# Altium schematic sheet MCU.SchDoc(MCU)
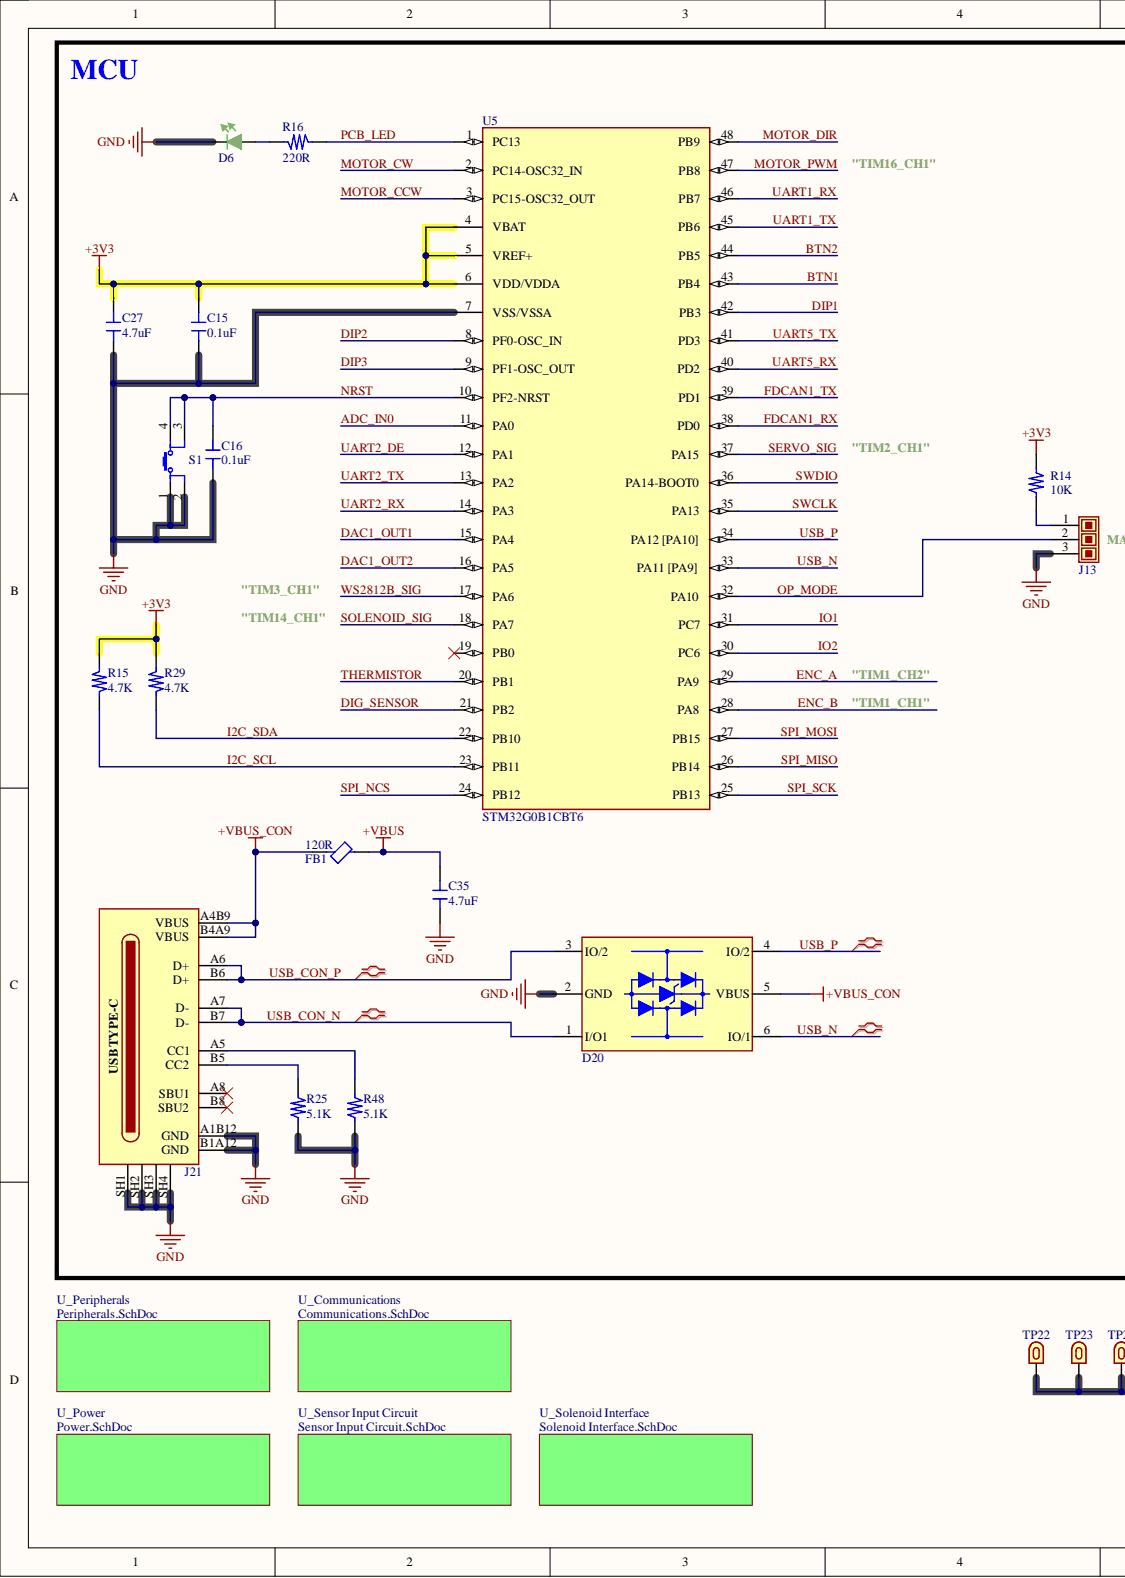
[diagram p0: MCU.SchDoc, left]
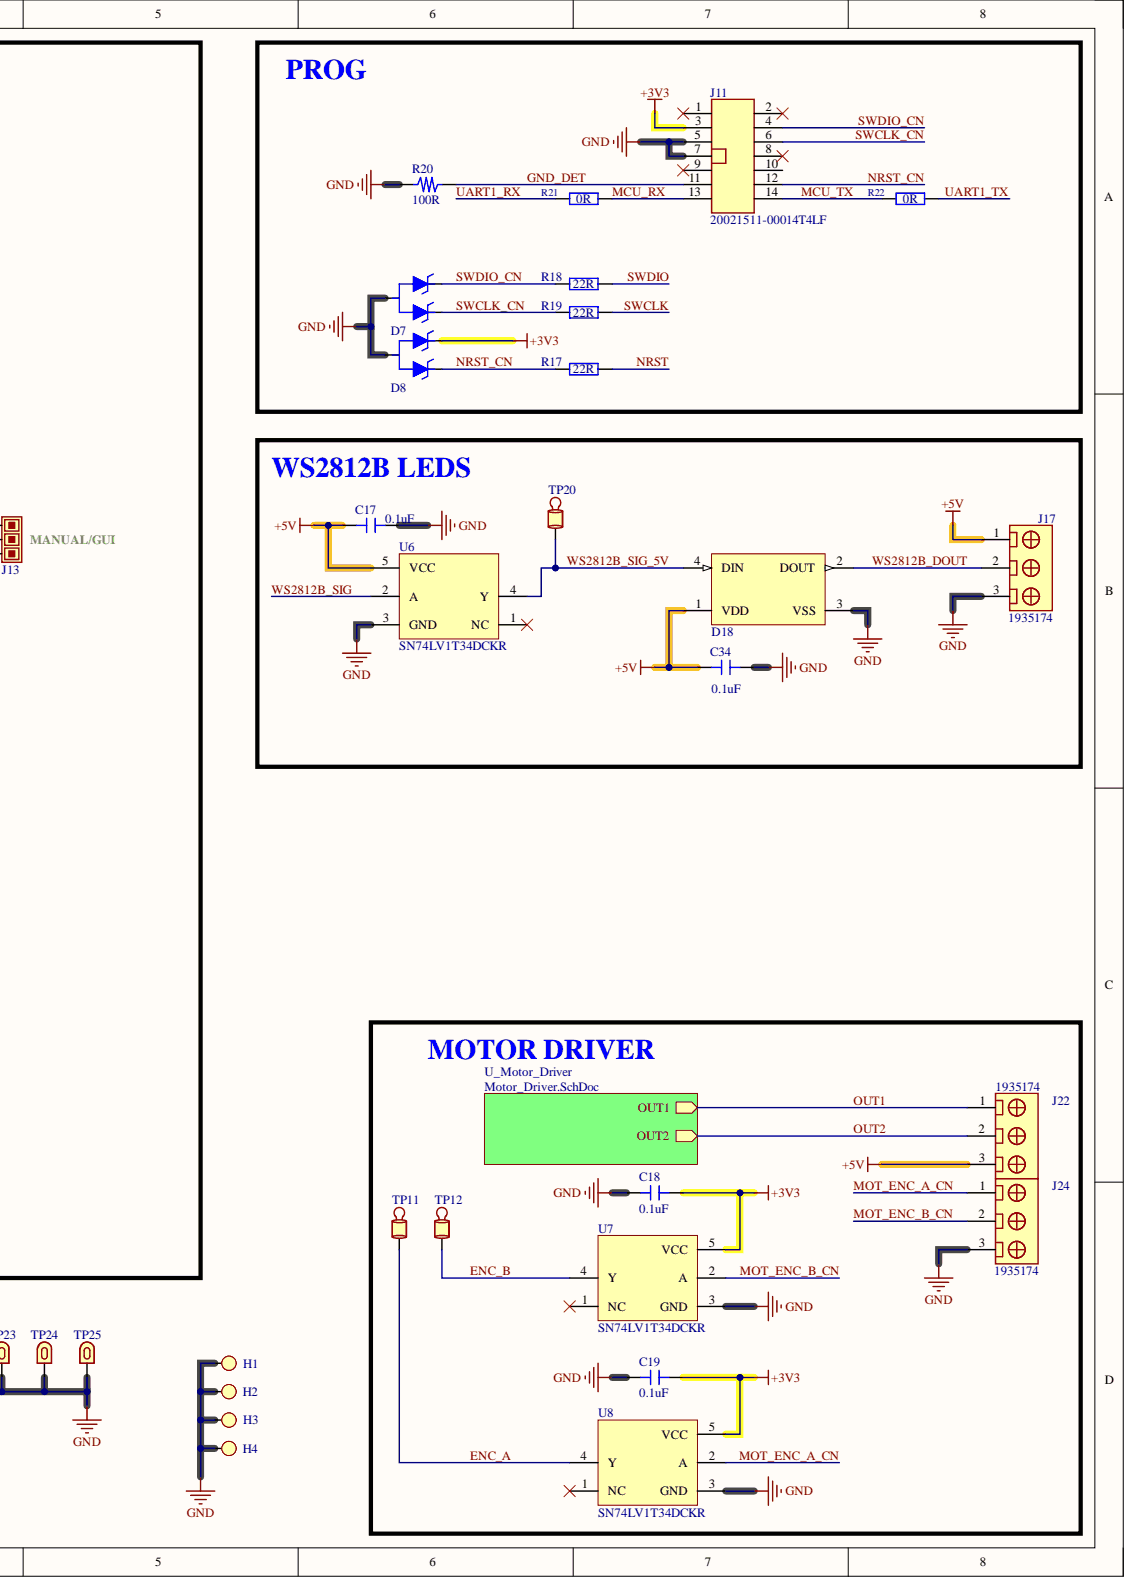
[diagram p1: MCU.SchDoc, right]

## Parts
C15  value 0.1uF  [CAP0603]  (hidden comment: GRM188R72A104KA35D)
C16  value 0.1uF  [CAP0603]  (hidden comment: GRM188R72A104KA35D)
C17  value 0.1uF  [CAP0603]  (hidden comment: GRM188R72A104KA35D)
C18  value 0.1uF  [CAP0603]  (hidden comment: GRM188R72A104KA35D)
C19  value 0.1uF  [CAP0603]  (hidden comment: GRM188R72A104KA35D)
C27  value 4.7uF  [CAP0603]  (hidden comment: GRM188Z71C475KE21D)
C34  value 0.1uF  [CAP0603]  (hidden comment: GRM188R72A104KA35D)
C35  value 4.7uF  [CAP0603]  (hidden comment: GRM188Z71C475KE21D)
D6  LG R971-KN-1-0-20-R18  [LED0805]  (value not drawn)
D7  DF3A6.8FUT1G  [SC70-3(SOT-323)]  (value not drawn)
D8  DF3A6.8FUT1G  [SC70-3(SOT-323)]  (value not drawn)
D18  16347  [LED5050]  (value not drawn)
D20  USBLC6-2SC6  [SOT23-6]  (value not drawn)
FB1  value 120R  [FER0603]  (hidden comment: BLM18KG121TN1D)
H1  MH3.2  [MoutingHole-M3.2_Plated]  (value not drawn)  (no BOM)
H2  MH3.2  [MoutingHole-M3.2_Plated]  (value not drawn)  (no BOM)
H3  MH3.2  [MoutingHole-M3.2_Plated]  (value not drawn)  (no BOM)
H4  MH3.2  [MoutingHole-M3.2_Plated]  (value not drawn)  (no BOM)
J11  20021511-00014T4LF  [20021511-00014T4LF]
J13  68001-203HLF  [3P-2.54mm-MalePins]  (value not drawn)
J17  1935174  [1935174]
J21  2171790001  [2171790001]  (value not drawn)
J22  1935174  [1935174]
J24  1935174  [1935174]
R14  value 10K  [RES0603]  (hidden comment: RC0603FR-0710KL)
R15  value 4.7K  [RES0603]  (hidden comment: RC0603FR-074K7L)
R16  value 220R  [RES0603]  (hidden comment: RC0603FR-07220RL)
R17  value 22R  [RES0603]  (hidden comment: RC0603FR-0722RL)
R18  value 22R  [RES0603]  (hidden comment: RC0603FR-0722RL)
R19  value 22R  [RES0603]  (hidden comment: RC0603FR-0722RL)
R20  value 100R  [RES0603]  (hidden comment: RC0603FR-07100RL)
R21  value 0R  [RES0603]  (hidden comment: RC0603FR-070RL)
R22  value 0R  [RES0603]  (hidden comment: RC0603FR-070RL)
R25  value 5.1K  [RES0603]  (hidden comment: RC0603FR-075K1L)
R29  value 4.7K  [RES0603]  (hidden comment: RC0603FR-074K7L)
R48  value 5.1K  [RES0603]  (hidden comment: RC0603FR-075K1L)
S1  PTS815SJM250SMTRLFS  [PTS815SJM250SMTRLFS]  (value not drawn)
TP11  5001  [5001]  (value not drawn)
TP12  5001  [5001]  (value not drawn)
TP20  5001  [5001]  (value not drawn)
TP22  4952  [4952]  (value not drawn)
TP23  4952  [4952]  (value not drawn)
TP24  4952  [4952]  (value not drawn)
TP25  4952  [4952]  (value not drawn)
U5  STM32G0B1CBT6  [LQFP-48(7x7)]
U6  SN74LV1T34DCKR  [SC-70-5]
U7  SN74LV1T34DCKR  [SC-70-5]
U8  SN74LV1T34DCKR  [SC-70-5]

## Nets
+3V3: C15.2 C18.1 C19.1 C27.1 D8.1 J11.3 R14.1 R15.1 R29.1 U5.4(VBAT) U5.5(VREF+) U5.6(VDD/VDDA) U7.5(VCC) U8.5(VCC)
+5V: C17.2 C34.2 D18.1(VDD) J17.1 J22.3 U6.5(VCC)
+VBUS: C35.1 FB1.2
+VBUS_CON: D20.5(VBUS) FB1.1 J21.A4B9(VBUS) J21.B4A9(VBUS)
GND: C15.1 C16.1 C17.1 C18.2 C19.2 C27.2 C34.1 C35.2 D6.K D7.3 D8.3 D18.3(VSS) D20.2(GND) H1.1 H2.1 H3.1 H4.1 J11.5 J11.7 J13.3 J17.3 J21.A1B12(GND) J21.B1A12(GND) J21.SH1 J21.SH2 J21.SH3 J21.SH4 J24.3 R20.2 R25.2 R48.2 S1.1 S1.2 TP22.1 TP23.1 TP24.1 TP25.1 U5.7(VSS/VSSA) U6.3(GND) U7.3(GND) U8.3(GND)
ADC_IN0: U5.11(PA0)
BTN1: U5.43(PB4)
BTN2: U5.44(PB5)
DAC1_OUT1: U5.15(PA4)
DAC1_OUT2: U5.16(PA5)
DIG_SENSOR: U5.21(PB2)
DIP1: U5.42(PB3)
DIP2: U5.8(PF0-OSC_IN)
DIP3: U5.9(PF1-OSC_OUT)
ENC_A: TP11.1 U5.29(PA9) U8.4(Y)
ENC_B: TP12.1 U5.28(PA8) U7.4(Y)
FDCAN1_RX: U5.38(PD0)
FDCAN1_TX: U5.39(PD1)
GND_DET: J11.11 R20.1
I2C_SCL: R15.2 U5.23(PB11)
I2C_SDA: R29.2 U5.22(PB10)
IO1: U5.31(PC7)
IO2: U5.30(PC6)
MCU_RX: J11.13 R21.1
MCU_TX: J11.14 R22.2
MOT_ENC_A_CN: J24.1 U8.2(A)
MOT_ENC_B_CN: J24.2 U7.2(A)
MOTOR_CCW: U5.3(PC15-OSC32_OUT)
MOTOR_CW: U5.2(PC14-OSC32_IN)
MOTOR_DIR: U5.48(PB9)
MOTOR_PWM: U5.47(PB8)
NRST: C16.2 R17.1 S1.3 S1.4 U5.10(PF2-NRST)
NRST_CN: D8.2 J11.12 R17.2
OP_MODE: J13.2 U5.32(PA10)
OUT1: J22.1
OUT2: J22.2
PCB_LED: R16.1 U5.1(PC13)
SERVO_SIG: U5.37(PA15)
SOLENOID_SIG: U5.18(PA7)
SPI_MISO: U5.26(PB14)
SPI_MOSI: U5.27(PB15)
SPI_NCS: U5.24(PB12)
SPI_SCK: U5.25(PB13)
SWCLK: R19.1 U5.35(PA13)
SWCLK_CN: D7.2 J11.6 R19.2
SWDIO: R18.1 U5.36(PA14-BOOT0)
SWDIO_CN: D7.1 J11.4 R18.2
THERMISTOR: U5.20(PB1)
UART1_RX: R21.2 U5.46(PB7)
UART1_TX: R22.1 U5.45(PB6)
UART2_DE: U5.12(PA1)
UART2_RX: U5.14(PA3)
UART2_TX: U5.13(PA2)
UART5_RX: U5.40(PD2)
UART5_TX: U5.41(PD3)
USB_CON_N: D20.1(I/O1) J21.A7(D-) J21.B7(D-)
USB_CON_P: D20.3(IO/2) J21.A6(D+) J21.B6(D+)
USB_N: D20.6(IO/1) U5.33(PA11_[PA9])
USB_P: D20.4(IO/2) U5.34(PA12_[PA10])
WS2812B_DOUT: D18.2(DOUT) J17.2
WS2812B_SIG: U5.17(PA6) U6.2(A)
WS2812B_SIG_5V: D18.4(DIN) TP20.1 U6.4(Y)
NetD6_A: D6.A R16.2
NetJ13_1: J13.1 R14.2
NetJ21_A5: J21.A5(CC1) R48.1
NetJ21_B5: J21.B5(CC2) R25.1

Not connected: J11 1 2 8 9 10; J21 A8(SBU1) B8(SBU2); U5 19(PB0); U6 1(NC); U7 1(NC); U8 1(NC)

## Library data of the parts
C15-C19 C34 = GRM188R72A104KA35D: 0.1 µF ±10% 100V Ceramic Capacitor X7R 0603 (1608 Metric); Manufacturer: Murata Electronics; Component Kind: Standard; ComponentLink1Description: Datasheet; ComponentLink1URL: https://search.murata.co.jp/Ceramy/image/img/A01X/G101/ENG/GRM188R72A104KA35-01.pdf; ComponentLink2Description: DigiKey Link; ComponentLink2URL: https://www.digikey.com/en/products/detail/murata-electronics/GRM188R72A104KA35D/702549; ComponentLink3Description: LCSC Link; ComponentLink3URL: https://lcsc.com/product-detail/Multilayer-Ceramic-Capacitors-MLCC-SMD-SMT_muRata-GRM188R72A104KA35D_C77058.html?s_z=n_GRM188R72A104KA35D; DigiKey PN: 490-3285-1-ND; LCSC PN: C77058; Library Name: 01-Capacitors_0603.IntLib; MPN: GRM188R72A104KA35D; Package: 0603; Temp Coefficient: X7R; Tolerance: ±10%; Voltage Rating: 100V
C27 C35 = GRM188Z71C475KE21D: 4.7 µF ±10% 16V Ceramic Capacitor X7R 0603 (1608 Metric); Manufacturer: Murata Electronics; Component Kind: Standard; ComponentLink1Description: Datasheet; ComponentLink1URL: https://search.murata.co.jp/Ceramy/image/img/A01X/G101/ENG/GRM188Z71C475KE21-01A.pdf; ComponentLink2Description: DigiKey Link; ComponentLink2URL: https://www.digikey.com/en/products/detail/murata-electronics/GRM188Z71C475KE21D/13401930; ComponentLink3Description: LCSC Link; ComponentLink3URL: https://www.lcsc.com/product-detail/C389010.html?s_z=n_GRM188Z71C475KE21D; DigiKey PN: 490-GRM188Z71C475KE21DCT-ND; LCSC PN: C389010; Library Name: 01-Capacitors_0603.IntLib; MPN: GRM188Z71C475KE21D; Package: 0603; Temp Coefficient: X7R; Tolerance: ±10%; Voltage Rating: 16V
D6 = LG R971-KN-1-0-20-R18: Green 570nm LED Indication - Discrete 2.2V 0805 (2012 Metric); Manufacturer: ams-OSRAM USA INC.; Component Kind: Standard; ComponentLink1Description: Datasheet; ComponentLink1URL: https://look.ams-osram.com/m/2da6f2ceeebf8fac/original/LG-R971.pdf; ComponentLink2Description: DigiKey Link; ComponentLink2URL: https://www.digikey.com/en/products/detail/ams-osram-usa-inc/LG-R971-KN-1-0-20-R18/1227925?s=N4IgTCBcDaIDIHEAEAlAnAdgIwFoDSAcjrgAw5hkpYAcIAugL5A; ComponentLink3Description: LCSC Link; ComponentLink3URL: https://lcsc.com/product-detail/LED-Indication-Discrete_OSRAM-LG-R971-KN-1-0-20-R18_C2899888.html?s_z=n_LG%2520R971-KN-1-0-20-R18; DigiKey PN: 475-LGR971-KN-1CT-ND; LCSC PN: C2899888; Library Name: 05-Optoelectronics.IntLib; MPN: LG R971-KN-1-0-20-R18; Package: 0805
D7 D8 = DF3A6.8FUT1G: 16V Clamp 9.37A (8/20µs) Ipp Tvs Diode Surface Mount SC-70-3 (SOT323); Manufacturer: onsemi; Component Kind: Standard; ComponentLink1Description: Datasheet; ComponentLink1URL: https://www.onsemi.com/pdf/datasheet/df3a6.8fut1-d.pdf; ComponentLink2Description: DigiKey Link; ComponentLink2URL: https://www.digikey.co.il/en/products/detail/onsemi/df3a6-8fut1g/918455; ComponentLink3Description: LCSC Link; ComponentLink3URL: https://lcsc.com/product-detail/ESD-and-Surge-Protection-TVS-ESD_onsemi-DF3A6-8FUT1G_C232543.html?s_z=n_DF3A6.8FUT1G; DigiKey PN: DF3A6.8FUT1GOSCT-ND; LCSC PN: C232543; Library Name: 12-Protection-TVS_Diodes.IntLib; MPN: DF3A6.8FUT1G; Package: SC-70-3(SOT323)
D18 = 16347: WS2812B - ADDRESSABLE LED RED/GRN/BLUE; Manufacturer: SparkFun Electronics; Component Kind: Standard; ComponentLink1Description: Datasheet; ComponentLink1URL: https://cdn.sparkfun.com/datasheets/BreakoutBoards/WS2812B.pdf; ComponentLink2Description: DigiKey Link; ComponentLink2URL: https://www.digikey.com/en/products/detail/sparkfun-electronics/16347/11630204; ComponentLink3Description: LCSC Alternative Link; ComponentLink3URL: https://www.lcsc.com/product-detail/C114586.html?s_z=n_ws2812b-b%252Fw; DigiKey Alternative PN: 4544-FZ2812-5050CT-ND; DigiKey PN: 1568-16347CT-ND; LCSC PN: C114586; Library Name: 05-Optoelectronics.IntLib; MPN: 16347; Package: 5050
D20 = USBLC6-2SC6: USB2.0 Data and VBUS, ESD Protection, 17V Clamp 5A (8/20µs) Ipp Tvs Diode Surface Mount SOT-23-6; Manufacturer: STMicroelectronics; Component Kind: Standard; ComponentLink1Description: Datasheet; ComponentLink1URL: https://www.st.com/content/ccc/resource/technical/document/datasheet/06/1d/48/9c/6c/20/4a/b2/CD00050750.pdf/files/CD00050750.pdf/jcr:content/translations/en.CD00050750.pdf; ComponentLink2Description: DigiKey Link; ComponentLink2URL: https://www.digikey.com/en/products/detail/stmicroelectronics/USBLC6-2SC6/1040559; ComponentLink3Description: LCSC Link; ComponentLink3URL: https://www.lcsc.com/product-detail/C7519.html?s_z=n_USBLC6-2; DigiKey PN: 497-5235-1-ND; LCSC PN: C7519; Library Name: 12-Protection-TVS_Diodes.IntLib; MPN: USBLC6-2SC6; Package: SC-70-3(SOT323)
FB1 = BLM18KG121TN1D: 120 Ohms @ 100 MHz 1 Power Line Ferrite Bead 0603 (1608 Metric) 3A 30mOhm; Manufacturer: Murata Electronics; Component Kind: Standard; ComponentLink1Description: Datasheet; ComponentLink1URL: https://www.murata.com/products/productdata/8796738650142/ENFA0003.pdf; ComponentLink2Description: DigiKey Link; ComponentLink2URL: https://www.digikey.com/en/products/detail/murata-electronics/BLM18KG121TN1D/1982762; ComponentLink3Description: LCSC Link; ComponentLink3URL: https://www.lcsc.com/product-detail/C85831.html?s_z=n_BLM18KG121TN1D; DigiKey PN: 490-5254-1-ND; LCSC PN: C85831; Library Name: 21-Filters.IntLib; MPN: BLM18KG121TN1D; Package: 0603
H1-H4 = MountingHole M3.2-Plated: Description: MountingHole M3.2-Plated; Library Name: 10-Mechanical.IntLib
J11 = 20021511-00014T4LF: Connector Header Through Hole 14 position 0.050" (1.27mm); Manufacturer: Amphenol ICC (FCI); Component Kind: Standard; ComponentLink1Description: Datasheet; ComponentLink1URL: https://cdn.amphenol-cs.com/media/wysiwyg/files/documentation/datasheet/boardwiretoboard/bwb_minitek127_btb.pdf; ComponentLink2Description: DigiKey Link; ComponentLink2URL: https://www.digikey.com/en/products/detail/amphenol-cs-fci/20021511-00014T4LF/4244990; ComponentLink3Description: LCSC Link; DigiKey PN: 609-20021511-00014T4LF-ND; Library Name: 11-Connectors_1.27mm.IntLib; MPN: 20021511-00014T4LF; Series: Minitek127® 20021511
J13 = 68001-203HLF: Connector Header Through Hole 3 position 0.100" (2.54mm); Manufacturer: Amphenol ICC (FCI); Component Kind: Standard; ComponentLink1Description: Datasheet; ComponentLink1URL: https://www.waldom.com/upload/parts/datasheets/mf-fci/fci-68001-203hlf.pdf; ComponentLink2Description: DigiKey Link; ComponentLink2URL: https://www.digikey.co.il/en/products/detail/amphenol-cs-fci/68001-203HLF/2023312?s=N4IgTCBcDaIGwA4AMSCMBaMSDMAJAMgGIgC6AvkA; ComponentLink3Description: LCSC Link; ComponentLink3URL: https://lcsc.com/product-detail/Pin-Headers_Amphenol-ICC-68001-203HLF_C5346215.html; DigiKey PN: 609-3470-ND; LCSC PN: C5346215; Library Name: 11-Connectors_2.54mm.IntLib; MPN: 68001-203HLF
J17 J22 J24 = 1935174: TB 5.00mm 3POS TH 18A, 300V, 12-26 AWG Wire; Manufacturer: Phoenix Contact; Component Kind: Standard; ComponentLink1Description: Datasheet; ComponentLink1URL: https://mm.digikey.com/Volume0/opasdata/d220001/medias/docus/1123/1935174.pdf; ComponentLink2Description: DigiKey Link; ComponentLink2URL: https://www.digikey.com/en/products/detail/phoenix-contact/1935174/568615; ComponentLink3Description: LCSC Link; ComponentLink3URL: https://www.lcsc.com/product-detail/C3816891.html; DigiKey PN: 277-1578-ND; LCSC PN: C3816891; Library Name: 11-Connectors_5.00mm.IntLib; MPN: 1935174; Series: COMBICON PT
J21 = 2171790001: USB-C (USB TYPE-C) USB 2.0 Receptacle Connector 24 (16+8 Dummy) Position Surface Mount, Right Angle; Through Hole; Manufacturer: Molex; Component Kind: Standard; ComponentLink1Description: Datasheet; ComponentLink1URL: https://www.molex.com/webdocs/datasheets/pdf/en-us/2171790001_IO_CONNECTORS.pdf; ComponentLink2Description: DigiKey Link; ComponentLink2URL: https://www.digikey.com/en/products/detail/molex/2171790001/13913749; ComponentLink3Description: LCSC Link; ComponentLink3URL: https://www.lcsc.com/product-detail/C3197684.html?s_z=n_2171790001; DigiKey PN: 900-2171790001CT-ND; LCSC PN: C3197684; Library Name: 11-Connectors_USB.IntLib; MPN: 2171790001
R14 = RC0603FR-0710KL: 10 kOhms ±1% 0.1W, 1/10W Chip Resistor 0603 (1608 Metric) Moisture Resistant Thick Film; Manufacturer: YAGEO; Component Kind: Standard; ComponentLink1Description: Datasheet; ComponentLink1URL: https://www.yageo.com/upload/media/product/products/datasheet/rchip/PYu-RC_Group_51_RoHS_L_12.pdf; ComponentLink2Description: DigiKey Link; ComponentLink2URL: https://www.digikey.com/en/products/detail/yageo/RC0603FR-0710KL/726880?s=N4IgTCBcDaIEoGEAMA2JBmAYnAtEg7AIxIDSAMiALoC%2BQA; ComponentLink3Description: LCSC Link; ComponentLink3URL: https://lcsc.com/product-detail/Chip-Resistor-Surface-Mount_YAGEO-RC0603FR-0710KL_C98220.html?s_z=n_RC0603FR-0710KL; DigiKey PN: 311-10.0KHRCT-ND; LCSC PN: C98220; Library Name: 00-Resistors_0603.IntLib; MPN: RC0603FR-0710KL; Package: 0603
R15 R29 = RC0603FR-074K7L: 4.7 kOhms ±1% 0.1W, 1/10W Chip Resistor 0603 (1608 Metric) Moisture Resistant Thick Film; Manufacturer: YAGEO; Component Kind: Standard; ComponentLink1Description: Datasheet; ComponentLink1URL: https://www.yageo.com/upload/media/product/products/datasheet/rchip/PYu-RC_Group_51_RoHS_L_12.pdf; ComponentLink2Description: DigiKey Link; ComponentLink2URL: https://www.digikey.com/en/products/detail/yageo/RC0603FR-074K7L/727212?s=N4IgTCBcDaIEoGEAMA2JBmAYnAtEg7ACwDS%2BAMiALoC%2BQA; ComponentLink3Description: LCSC Link; ComponentLink3URL: https://lcsc.com/product-detail/Chip-Resistor-Surface-Mount_YAGEO-RC0603FR-074K7L_C99782.html?s_z=n_RC0603FR-074K7L; DigiKey PN: 311-4.70KHRCT-ND; LCSC PN: C99782; Library Name: 00-Resistors_0603.IntLib; MPN: RC0603FR-074K7L; Package: 0603
R16 = RC0603FR-07220RL: 220 Ohms ±1% 0.1W, 1/10W Chip Resistor 0603 (1608 Metric) Moisture Resistant Thick Film; Manufacturer: YAGEO; Component Kind: Standard; ComponentLink1Description: Datasheet; ComponentLink1URL: https://www.yageo.com/upload/media/product/products/datasheet/rchip/PYu-RC_Group_51_RoHS_L_12.pdf; ComponentLink2Description: DigiKey Link; ComponentLink2URL: https://www.digikey.com/en/products/detail/yageo/RC0603FR-07220RL/727061?s=N4IgTCBcDaIEoGEAMA2JBmAYnAtEg7GGEnADIgC6AvkA; ComponentLink3Description: LCSC Link; ComponentLink3URL: https://lcsc.com/product-detail/Chip-Resistor-Surface-Mount_YAGEO-RC0603FR-07220RL_C107696.html?s_z=n_RC0603FR-07220RL; DigiKey PN: 311-220HRCT-ND; LCSC PN: C107696; Library Name: 00-Resistors_0603.IntLib; MPN: RC0603FR-07220RL; Package: 0603
R17-R19 = RC0603FR-0722RL: 22 Ohms ±1% 0.1W, 1/10W Chip Resistor 0603 (1608 Metric) Moisture Resistant Thick Film; Manufacturer: YAGEO; Component Kind: Standard; ComponentLink1Description: Datasheet; ComponentLink1URL: https://www.yageo.com/upload/media/product/products/datasheet/rchip/PYu-RC_Group_51_RoHS_L_12.pdf; ComponentLink2Description: DigiKey Link; ComponentLink2URL: https://www.digikey.com/en/products/detail/yageo/RC0603FR-0722RL/727055?s=N4IgTCBcDaIEoGEAMA2JBmAYnAtEg7GGAE4AyIAugL5A; ComponentLink3Description: LCSC Link; ComponentLink3URL: https://www.lcsc.com/product-detail/C107701.html?s_z=n_RC0603FR-0722rL; DigiKey PN: 311-22.0HRCT-ND; LCSC PN: C107701; Library Name: 00-Resistors_0603.IntLib; MPN: RC0603FR-0722RL; Package: 0603
R20 = RC0603FR-07100RL: 100 Ohms ±1% 0.1W, 1/10W Chip Resistor 0603 (1608 Metric) Moisture Resistant Thick Film; Manufacturer: YAGEO; Component Kind: Standard; ComponentLink1Description: Datasheet; ComponentLink1URL: https://www.yageo.com/upload/media/product/products/datasheet/rchip/PYu-RC_Group_51_RoHS_L_12.pdf; ComponentLink2Description: DigiKey Link; ComponentLink2URL: https://www.digikey.co.il/en/products/detail/yageo/RC0603FR-07100RL/726888?s=N4IgTCBcDaIEoGEAMA2JBmAYnAtEg7AIxJJwAyIAugL5A; ComponentLink3Description: LCSC Link; ComponentLink3URL: https://lcsc.com/product-detail/Chip-Resistor-Surface-Mount_YAGEO-RC0603FR-07100RL_C105588.html?s_z=n_RC0603FR-07100RL; DigiKey PN: 311-100HRCT-ND; LCSC PN: C105588; Library Name: 00-Resistors_0603.IntLib; MPN: RC0603FR-07100RL; Package: 0603
R21 R22 = RC0603FR-070RL_1: 0 Ohms Jumper Chip Resistor 0603 (1608 Metric) Moisture Resistant Thick Film; Manufacturer: YAGEO; Component Kind: Standard; ComponentLink1Description: Datasheet; ComponentLink1URL: https://www.yageo.com/upload/media/product/products/datasheet/rchip/PYu-RC_Group_51_RoHS_L_12.pdf; ComponentLink2Description: DigiKey Link; ComponentLink2URL: https://www.digikey.com/en/products/detail/yageo/RC0603FR-070RL/1304008?s=N4IgTCBcDaIEoGEAMA2JBmAYnAtEg7EnADIgC6AvkA; ComponentLink3Description: LCSC Link; ComponentLink3URL: https://lcsc.com/product-detail/Chip-Resistor-Surface-Mount_YAGEO-RC0603FR-070RL_C100044.html?s_z=n_RC0603FR-070RL; DigiKey PN: 311-0.0HRCT-ND; LCSC PN: C100044; Library Name: 00-Resistors_0603.IntLib; MPN: RC0603FR-070RL; Package: 0603
R25 R48 = RC0603FR-075K1L: 5.1 kOhms ±1% 0.1W, 1/10W Chip Resistor 0603 (1608 Metric) Moisture Resistant Thick Film; Manufacturer: YAGEO; Component Kind: Standard; ComponentLink1Description: Datasheet; ComponentLink1URL: https://www.yageo.com/upload/media/product/products/datasheet/rchip/PYu-RC_Group_51_RoHS_L_12.pdf; ComponentLink2Description: DigiKey Link; ComponentLink2URL: https://www.digikey.com/en/products/detail/yageo/RC0603FR-075K1L/727268?s=N4IgTCBcDaIEoGEAMA2JBmAYnAtEg7AKwDSAjADIgC6AvkA; ComponentLink3Description: LCSC Link; ComponentLink3URL: https://www.lcsc.com/product-detail/C105580.html?s_z=n_RC0603FR-075K1L; DigiKey PN: 311-5.10KHRCT-ND; LCSC PN: C105580; Library Name: 00-Resistors_0603.IntLib; MPN: RC0603FR-075K1L; Package: 0603
S1 = PTS815SJM250SMTRLFS: SWITCH TACTILE SPST-NO 0.05A 12V; Manufacturer: C&K; Component Kind: Standard; ComponentLink1Description: Datasheet; ComponentLink1URL: https://www.ckswitches.com/media/2728/pts815.pdf; ComponentLink2Description: DigiKey Link; ComponentLink2URL: https://www.digikey.co.il/en/products/detail/c-k/PTS815SJM250SMTRLFS/9947846?srsltid=AfmBOorU8ILluuQKY9JbiSp4CL58IbF5FCi6OX1a_DI5zeYjtplMXkcE; ComponentLink3Description: LCSC Link; ComponentLink3URL: https://lcsc.com/product-detail/Tactile-Switches_C-K-PTS815SJM250SMTRLFS_C2689510.html?s_z=n_PTS815SJM250SMTRLFS; ComponentLink4Description: LCSC Alternative PN; ComponentLink4URL: https://lcsc.com/product-detail/Tactile-Switches_G-Switch-GT-TC048A-H025-L1_C778166.html?s_z=n_PTS815SJM250SMTRLFS; DigiKey PN: CKN12215-1-ND; LCSC Alternative PN: C778166; LCSC PN: C2689510; Library Name: 10-Mechanical.IntLib; MPN: PTS815SJM250SMTRLFS; Package: SMA(DO-214AC)
TP11 TP12 TP20 = 5001: TEST POINT RING, BACK - TH; Manufacturer: Keystone Electronics; Component Kind: Standard; ComponentLink1Description: Datasheet; ComponentLink1URL: https://www.keyelco.com/userAssets/file/M65p56.pdf; ComponentLink2Description: DigiKey Link; ComponentLink2URL: https://www.digikey.com/en/products/detail/keystone-electronics/5001/255327; ComponentLink3Description: LCSC Link; ComponentLink3URL: https://www.lcsc.com/product-detail/C238122.html; DigiKey PN: 36-5001-ND; LCSC PN: C238122; Library Name: 09-TestPoints.IntLib; MPN: 5001
TP22-TP25 = 4952: PC TEST POINT LOOP; Manufacturer: Keystone Electronics; Component Kind: Standard; ComponentLink1Description: Datasheet; ComponentLink1URL: https://www.keyelco.com/userAssets/file/M65p57.pdf; ComponentLink2Description: DigiKey Link; ComponentLink2URL: https://www.digikey.com/en/products/detail/keystone-electronics/4952/316326; ComponentLink3Description: LCSC Link; ComponentLink3URL: https://www.lcsc.com/product-detail/C238118.html; DigiKey PN: 36-4952-ND; LCSC PN: C238118; Library Name: 09-TestPoints.IntLib; MPN: 4952
U5 = STM32G0B1CBT6: ARM® Cortex®-M0+ STM32G0 Microcontroller IC 32-Bit 64MHz 128KB (128K x 8) FLASH 48-LQFP (7x7); Manufacturer: STMicroelectronics; Component Kind: Standard; ComponentLink1Description: Datasheet; ComponentLink1URL: https://www.st.com/resource/en/datasheet/stm32g0b1ce.pdf; ComponentLink2Description: DigiKey Link; ComponentLink2URL: https://www.digikey.com/en/products/detail/stmicroelectronics/STM32G0B1CBT6/18086231; ComponentLink3Description: LCSC Link; ComponentLink3URL: https://www.lcsc.com/product-detail/C2847904.html?s_z=n_STM32G0B1CBT6; DigiKey PN: 497-STM32G0B1CBT6-ND; LCSC PN: C2847904; Library Name: 07-Microcontrollers.IntLib; MPN: STM32G0B1CBT6; Package: LQFP-48(7x7)
U6-U8 = SN74LV1T34DCKR: Logic Level Shifter, 5V <> 3.3V, Single Supply, SOT-23; Manufacturer: Texas Instruments; Component Kind: Standard; ComponentLink1Description: Datasheet; ComponentLink1URL: https://www.ti.com/general/docs/suppproductinfo.tsp?distId=10&gotoUrl=https%3A%2F%2Fwww.ti.com%2Flit%2Fgpn%2Fsn74lv1t34; ComponentLink2Description: DigiKey Link; ComponentLink2URL: https://www.digikey.com/en/products/detail/texas-instruments/SN74LV1T34DCKR/4555576; ComponentLink3Description: LCSC Link; ComponentLink3URL: https://www.lcsc.com/product-detail/C78541.html?s_z=n_SN74LV1T34DCKR; DigiKey PN: 296-37177-1-ND; LCSC PN: C78541; Library Name: 14-Interface_ICs.IntLib; MPN: SN74LV1T34DCKR; Package: SC-70-5
